# Altium schematic sheet Display.SchDoc
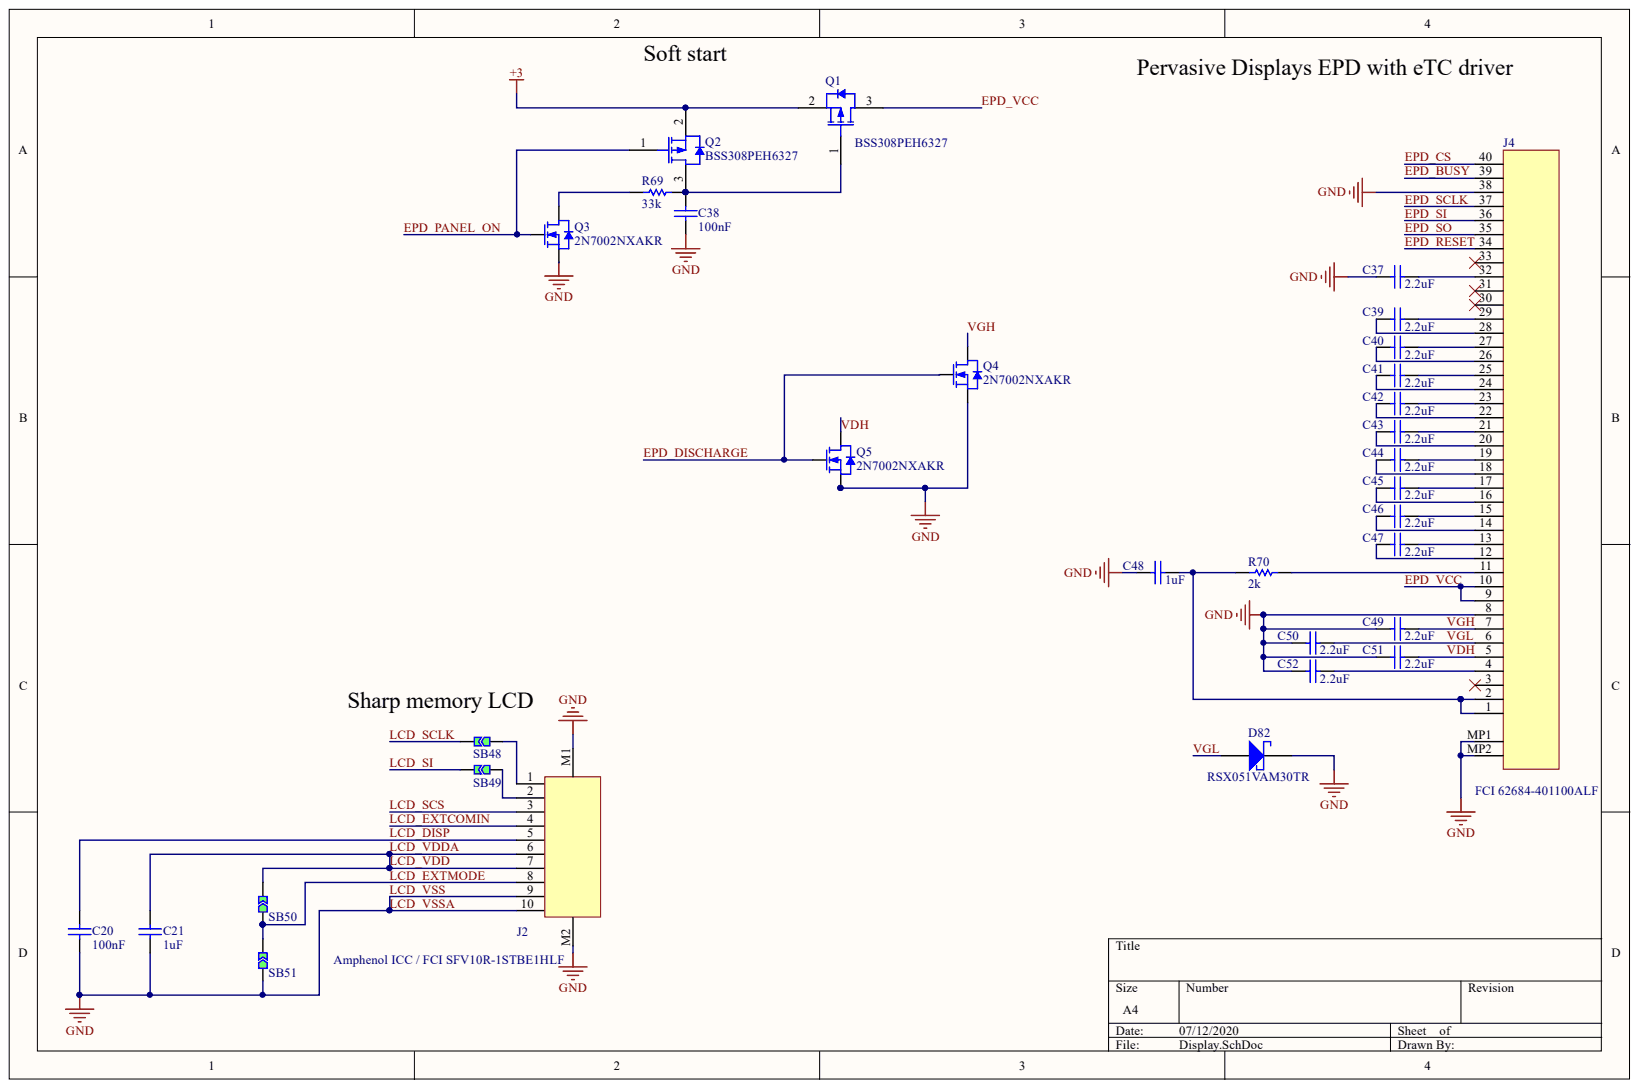
[diagram p0: Display.SchDoc]

## Parts
C20  100nF  [PCB-srj5nzvxxiuyr0ufdy6u-1]
C21  1uF  [CAPC1608X08N]
C37  2.2uF  [CAPC1608X08N]
C38  100nF  [PCB-srj5nzvxxiuyr0ufdy6u-1]
C39  2.2uF  [CAPC1608X08N]
C40  2.2uF  [CAPC1608X08N]
C41  2.2uF  [CAPC1608X08N]
C42  2.2uF  [CAPC1608X08N]
C43  2.2uF  [CAPC1608X08N]
C44  2.2uF  [CAPC1608X08N]
C45  2.2uF  [CAPC1608X08N]
C46  2.2uF  [CAPC1608X08N]
C47  2.2uF  [CAPC1608X08N]
C48  1uF  [CAPC1608X08N]
C49  2.2uF  [CAPC1608X08N]
C50  2.2uF  [CAPC1608X08N]
C51  2.2uF  [CAPC1608X08N]
C52  2.2uF  [CAPC1608X08N]
D82  RSX051VAM30TR  [PCB-pdf5zse1jkbqdpg1nzvf-1]
J2  Amphenol ICC / FCI SFV10R-1STBE1HLF  [PCB-w9niq6n6zm0cwiu5nkjp-1]
J4  FCI 62684-401100ALF  Manufacturer Part Number: 62684-401100ALF  [PCB-88m8m48jei8r9hip1xda-1]
Q1  BSS308PEH6327  [ADI-RT-3_L]
Q2  BSS308PEH6327  [ADI-RT-3_L]
Q3  2N7002NXAKR  [PCB-lygu1wj07d1mwbs3aw73-1]
Q4  2N7002NXAKR  [PCB-lygu1wj07d1mwbs3aw73-1]
Q5  2N7002NXAKR  [PCB-lygu1wj07d1mwbs3aw73-1]
R69  33k  [RESC1005X04M]
R70  2k  [RESC1005X04M]
SB48  Solder Bridge 0402  [PCB-zgo6wgtf9tfvr4qcvek3-1]  (value not drawn)
SB49  Solder Bridge 0402  [PCB-zgo6wgtf9tfvr4qcvek3-1]  (value not drawn)
SB50  Solder Bridge 0402  [PCB-zgo6wgtf9tfvr4qcvek3-1]  (value not drawn)
SB51  Solder Bridge 0402  [PCB-zgo6wgtf9tfvr4qcvek3-1]  (value not drawn)

## Nets
+3: Q1.2 Q2.2
EPD_BUSY: J4.39
EPD_CS: J4.40
EPD_DISCHARGE: Q4.1 Q5.1
EPD_PANEL_ON: Q2.1 Q3.1
EPD_RESET: J4.34
EPD_SCLK: J4.37
EPD_SI: J4.36
EPD_SO: J4.35
EPD_VCC: J4.9 J4.10 Q1.3
LCD_DISP: C20.2 J2.5
LCD_EXTCOMIN: J2.4
LCD_EXTMODE: J2.8 SB50.2 SB51.1
LCD_SCLK: SB48.1
LCD_SCS: J2.3
LCD_SI: SB49.1
LCD_VDD: C21.2 J2.6 J2.7 SB50.1
LCD_VSS: C20.1 C21.1 C37.2 C38.1 C48.2 C49.2 C50.2 C51.2 C52.2 D82.C J2.9 J2.10 J2.M1 J2.M2 J4.8 J4.38 J4.MP1 J4.MP2 Q3.2 Q4.2 Q5.2 SB51.2
VDH: C51.1 J4.5 Q5.3
VGH: C49.1 J4.7 Q4.3
VGL: C50.1 D82.A J4.6
NetC37_1: C37.1 J4.32
NetC38_2: C38.2 Q1.1 Q2.3 R69.1
NetC39_1: C39.1 J4.29
NetC39_2: C39.2 J4.28
NetC40_1: C40.1 J4.27
NetC40_2: C40.2 J4.26
NetC41_1: C41.1 J4.25
NetC41_2: C41.2 J4.24
NetC42_1: C42.1 J4.23
NetC42_2: C42.2 J4.22
NetC43_1: C43.1 J4.21
NetC43_2: C43.2 J4.20
NetC44_1: C44.1 J4.19
NetC44_2: C44.2 J4.18
NetC45_1: C45.1 J4.17
NetC45_2: C45.2 J4.16
NetC46_1: C46.1 J4.15
NetC46_2: C46.2 J4.14
NetC47_1: C47.1 J4.13
NetC47_2: C47.2 J4.12
NetC48_1: C48.1 J4.1 J4.2 R70.2
NetC52_1: C52.1 J4.4
NetJ2_1: J2.1 SB48.2
NetJ2_2: J2.2 SB49.2
NetJ4_11: J4.11 R70.1
NetQ3_3: Q3.3 R69.2

Not connected: J4 3 30 31 33

## Library data of the parts
C20 C38 = CMP-16527024-7: 0402 100nF 6.3V X5R; Capacitance Tolerance: ±10%; Lead-Free Status: Lead Free; Voltage Rating (DC): 16.0 V; Capacitance: 100 nF; Case/Package: 0402; Component Kind: Standard; Dielectric Characteristic: X5R; Library Name: CommunityVault; Mounting Style: Surface Mount; Number of Pins: 2; OctopartID: 6877a17fb5d5b7b0; Operating Temperature: -55.0 °C to 85.0 °C; Packaging: Cut Tape (CT), Reel, Tape & Reel (TR); REACH SVHC Compliance: No SVHC; RoHS: Compliant; Size-Height: 560 µm; Size-Length: 1.00 mm; Size-Width: 500 µm
C21 = CMP-nocyn7nhex2srcneo663-1: 0603 1uF 10V X5R; Body Height: 0.8mm; Body Length: 1.6mm; Capacitance: 100nF; Package (Imperial): 0603; Package (Metric): 1608; Tolerance: 10%; Body Width: 0.8mm; Component Kind: Standard; CustomComponentID: nocyn7nhex2srcneo663; Library Name: CommunityVault
C37 = CMP-nocyn7nhex2srcneo663-1: 0603 2.2uF 10V X5R; Body Height: 0.8mm; Body Length: 1.6mm; Capacitance: 100nF; Package (Imperial): 0603; Package (Metric): 1608; Tolerance: 10%; Body Width: 0.8mm; Component Kind: Standard; CustomComponentID: nocyn7nhex2srcneo663; Library Name: CommunityVault
C39 C44-C47 = CMP-nocyn7nhex2srcneo663-1: 0603 2.2uF 16V X5R; Body Height: 0.8mm; Body Length: 1.6mm; Capacitance: 100nF; Package (Imperial): 0603; Package (Metric): 1608; Tolerance: 10%; Body Width: 0.8mm; Component Kind: Standard; CustomComponentID: nocyn7nhex2srcneo663; Library Name: CommunityVault
C40-C43 C49-C52 = CMP-nocyn7nhex2srcneo663-1: 0603 2.2uF 25V X5R; Body Height: 0.8mm; Body Length: 1.6mm; Capacitance: 100nF; Package (Imperial): 0603; Package (Metric): 1608; Tolerance: 10%; Body Width: 0.8mm; Component Kind: Standard; CustomComponentID: nocyn7nhex2srcneo663; Library Name: CommunityVault
C48 = CMP-nocyn7nhex2srcneo663-1: 0603 1uF 10V X7R; Body Height: 0.8mm; Body Length: 1.6mm; Capacitance: 100nF; Package (Imperial): 0603; Package (Metric): 1608; Tolerance: 10%; Body Width: 0.8mm; Component Kind: Standard; CustomComponentID: nocyn7nhex2srcneo663; Library Name: CommunityVault
D82 = CMP-5d68976b40d282af-2: Schottky diode, Vf<0.25V@10mA/25°C Vrrm>25V SOD-323HE; Lead-Free Status: Lead Free; Component Kind: Standard; Library Name: CommunityVault; Operating Temperature: 150 °C (max)
J2 = CMP-af096731f4ae70ce-2: Conn FFC/FPC Connector SKT 10 POS 0.5mm Solder RA SMD Embossed T/R; Component Kind: Standard; Library Name: CommunityVault; Mounting Style: Surface Mount; OctopartID: af096731f4ae70ce
J4 = CMP-fb5030001dd52497-3: Conn; Rect; FPC/FFC; 40Cnt; SMT; OPUSeries; ZIF; 0.5mmPitch; RtAngle; Gender: Female; Lead-Free Status: Lead Free; Number of Positions: 40; Component Kind: Standard; Housing Material: Plastic; Library Name: CommunityVault; Octopart URL: http://octopart.com/62684-401100alf-fci-39502819; Size-Height: 2.00 mm
Q1 Q2 = CMP-4157397-7: BSS308PEH6327 MOSFET P-CH RdsOn<0.5R VGSth<2.5V; Manufacturer Part Number: BSS308PEH6327XTSA1; Component Kind: Standard; Library Name: CommunityVault; OctopartID: 1c42d4cf736ac385; Octopart Url: https://octopart.com/bss308peh6327-infineon-25643598
Q3-Q5 = CMP-ee2f2100224d31e8-3: 2N7002NXAK - 60 V, single N-channel Trench MOSFET; Component Kind: Standard; Library Name: CommunityVault
R69 = CMP-v99hy84itq0asdedzg70-1: 0402 33k 5%; Body Height: 0.35mm; Body Length: 1mm; Body Width: 0.5mm; Package (Imperial): 0402; Package (Metric): 01005; Resistance: 10K?; Tolerance: 1%; Component Kind: Standard; CustomComponentID: v99hy84itq0asdedzg70; Library Name: CommunityVault
R70 = CMP-v99hy84itq0asdedzg70-1: 0402 2k 5%; Body Height: 0.35mm; Body Length: 1mm; Body Width: 0.5mm; Package (Imperial): 0402; Package (Metric): 01005; Resistance: 10K?; Tolerance: 1%; Component Kind: Standard; CustomComponentID: v99hy84itq0asdedzg70; Library Name: CommunityVault
SB48-SB51 = CMP-p5m5ndkvhoystooqawk9-5: Solder Bridge Jumper, can be fitted with 0402 0R resistor for automated production; State: Open / Closed; Component Kind: Standard; CustomComponentID: p5m5ndkvhoystooqawk9; Library Name: FavoritesLibrary
